# Altium schematic sheet dv_analog_main.SchDoc(dv_analog_main)
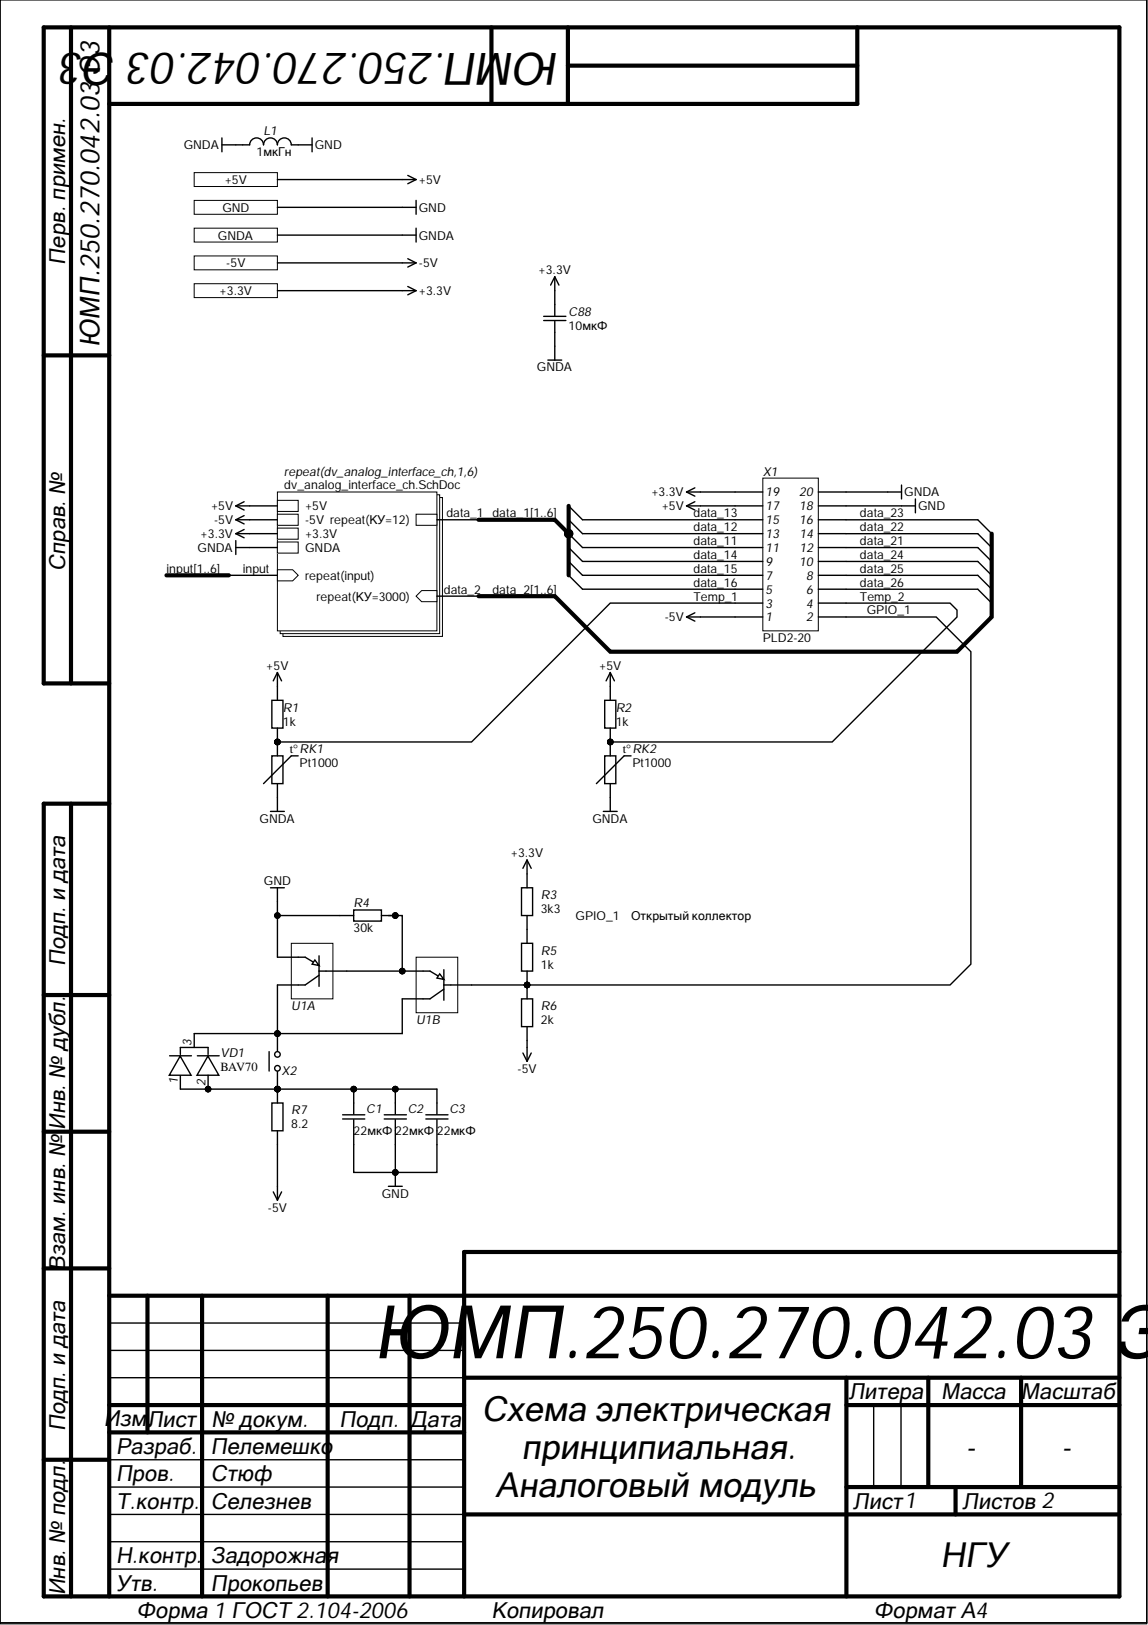
[diagram p0: dv_analog_main.SchDoc]

## Parts
C1  value 22мкФ  [SMD_C1206]  (hidden comment: K10-79)
C2  value 22мкФ  [SMD_C1206]  (hidden comment: K10-79)
C3  value 22мкФ  [SMD_C1206]  (hidden comment: K10-79)
C88  value 10мкФ  [SMD_C0805]  (hidden comment: K10-79)
L1  value 1мкГн  [SMD_0805]  (hidden comment: Inductor)
R1  value 1k  [SMD_0805]  (hidden comment: OSM R1-12)
R2  value 1k  [SMD_0805]  (hidden comment: OSM R1-12)
R3  value 3k3  [SMD_0805]  (hidden comment: OSM R1-12)
R4  value 30k  [SMD_0805]  (hidden comment: OSM R1-12)
R5  value 1k  [SMD_0805]  (hidden comment: OSM R1-12)
R6  value 2k  [SMD_0805]  (hidden comment: OSM R1-12)
R7  value 8.2  [SMD_0805]  (hidden comment: OSM R1-12)
RK1  Pt1000  [Pt1000_3.0x1.0]
RK2  Pt1000  [Pt1000_3.0x1.0]
U1  2ТС622А1 OC  [14PIN FLATPACK]  (value not drawn)  (parts A,B)
VD1  BAV70  [SOT-23]
X1  Header 2X20  [PLD2-20]  (value not drawn)
X2  Jumper 2  [PLS-2]  (value not drawn)

## Ports
+3.3V, +5V, -5V, GND, GNDA

## Nets
+3.3V: C88.2 R3.2 X1.19 ; port +3.3V
+5V: R1.2 R2.2 X1.17 ; port +5V
-5V: R6.1 R7.2 X1.1 ; port -5V
data_11: X1.11  [also labelled data_1]
data_12: X1.13  [also labelled data_1]
data_13: X1.15  [also labelled data_1]
data_14: X1.9  [also labelled data_1]
data_15: X1.7  [also labelled data_1]
data_16: X1.5  [also labelled data_1]
data_21: X1.12  [also labelled data_2]
data_22: X1.14  [also labelled data_2]
data_23: X1.16  [also labelled data_2]
data_24: X1.10  [also labelled data_2]
data_25: X1.8  [also labelled data_2]
data_26: X1.6  [also labelled data_2]
GND: C1.2 C2.2 C3.2 L1.2 R4.2 U1.13 X1.18 ; port GND
GNDA: C88.1 L1.1 RK1.2 RK2.2 X1.20 ; port GNDA
GPIO_1: R5.1 R6.2 U1.12 X1.2
Temp_1: R1.1 RK1.1 X1.3
Temp_2: R2.1 RK2.1 X1.4
NetC1_1: C1.1 C2.1 C3.1 R7.1 VD1.1 VD1.2 X2.1
NetR3_1: R3.1 R5.2
NetR4_1: R4.1 U1.2 U1.3
NetU1_4: U1.4 U1.14 VD1.3 X2.2
input1:  [also labelled input]
input2:  [also labelled input]
input3:  [also labelled input]
input4:  [also labelled input]
input5:  [also labelled input]
input6:  [also labelled input]

## Library data of the parts
C1-C3 C88 = Capacitor: Назначение: SMD-конденсатор; Наименование: К10-79; Напряжение: В; Потребление, Вт: 0; Точность: %; Component Kind: Standard; Description: Capacitor; Library Name: Passive.IntLib
L1 = Inductor: Назначение: SMD-индуктивность; Наименование: Индуктивность; Потребление, Вт: 0,010; Component Kind: Standard; Description: Inductor; Library Name: Passive.IntLib
R1-R7 = Resistor: Назначение: SMD-резистор; Наименование: ОСМ Р1-12; Потребление, Вт: 0,001; Точность: %; Component Kind: Standard; Description: Resistor; Library Name: Passive.IntLib
RK1 RK2 = Pt1000: Платиновый термометр сопротивления; Value: 1000; Потребление, Вт: 0,001; Component Kind: Standard; ComponentLink1Description: DataSheet; ComponentLink1URL: https://ru.mouser.com/datasheet/2/619/M310_HST-USA-1131966.pdf; Library Name: Sensor.IntLib; Назначение: Термометр сопротивления; Наименование: Pt1000
U1 = 2TS622A1 OS: Назначение: Матрица транзисторная; Component Kind: Standard; Library Name: Transistor.IntLib; Наименование: 2ТС622А1 OC
VD1 = BAV70: Назначение: Диодная сборка; Component Kind: Standard; ComponentLink1Description: DataSheet; ComponentLink1URL: https://static.chipdip.ru/lib/635/DOC005635893.pdf; Наименование: BAV70; Library Name: Diod.IntLib
X1 = Header 2X20: Header, 20-Pin, Dual row; Потребление, Вт: 0,0; Component Kind: Standard; Library Name: Connector.IntLib; Назначение: Header, 20-Pin, Dual row; Наименование: PLD2-20
X2 = Jumper 2: Jumper, 2-Pin, Dual row; Наименование: Jumper 2-pin; Потребление, Вт: 0,0; Component Kind: Standard; Library Name: Connector.IntLib; Назначение: Jumper, 2-Pin, Dual row
